# Altium schematic sheet UWB_Transceiver.SchDoc(U_UWB_Transceiver)
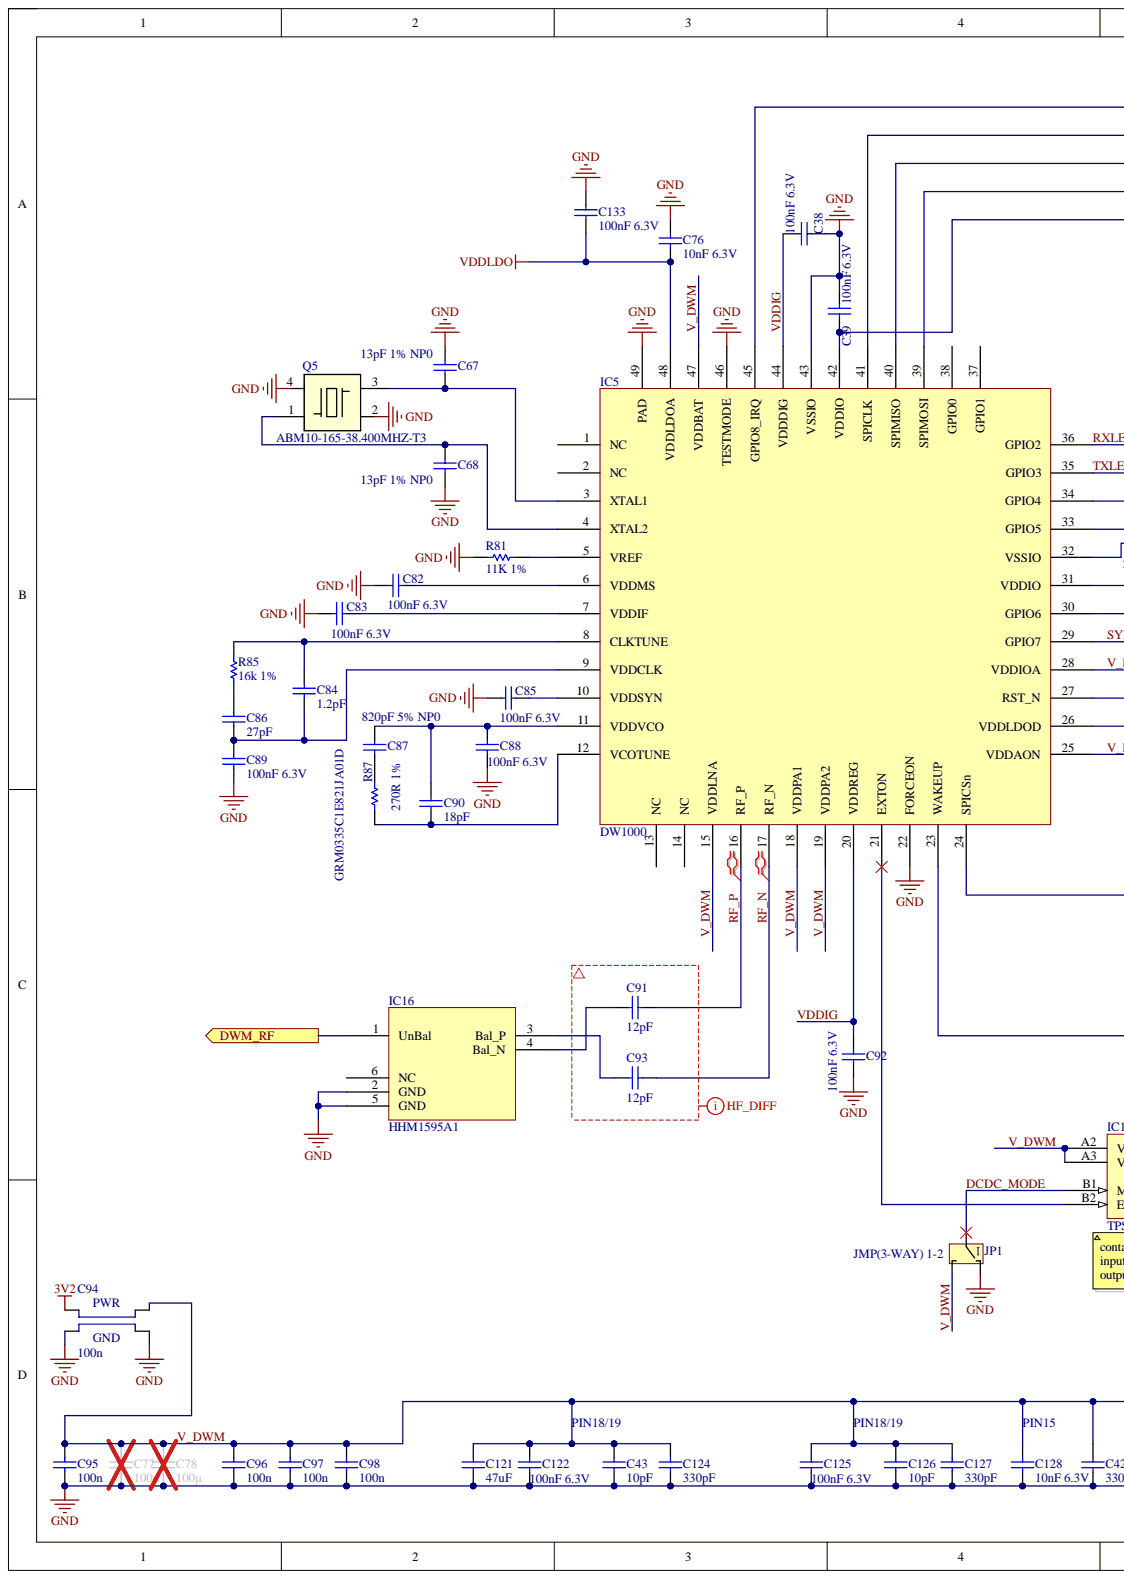
[diagram p0: UWB_Transceiver.SchDoc, left]
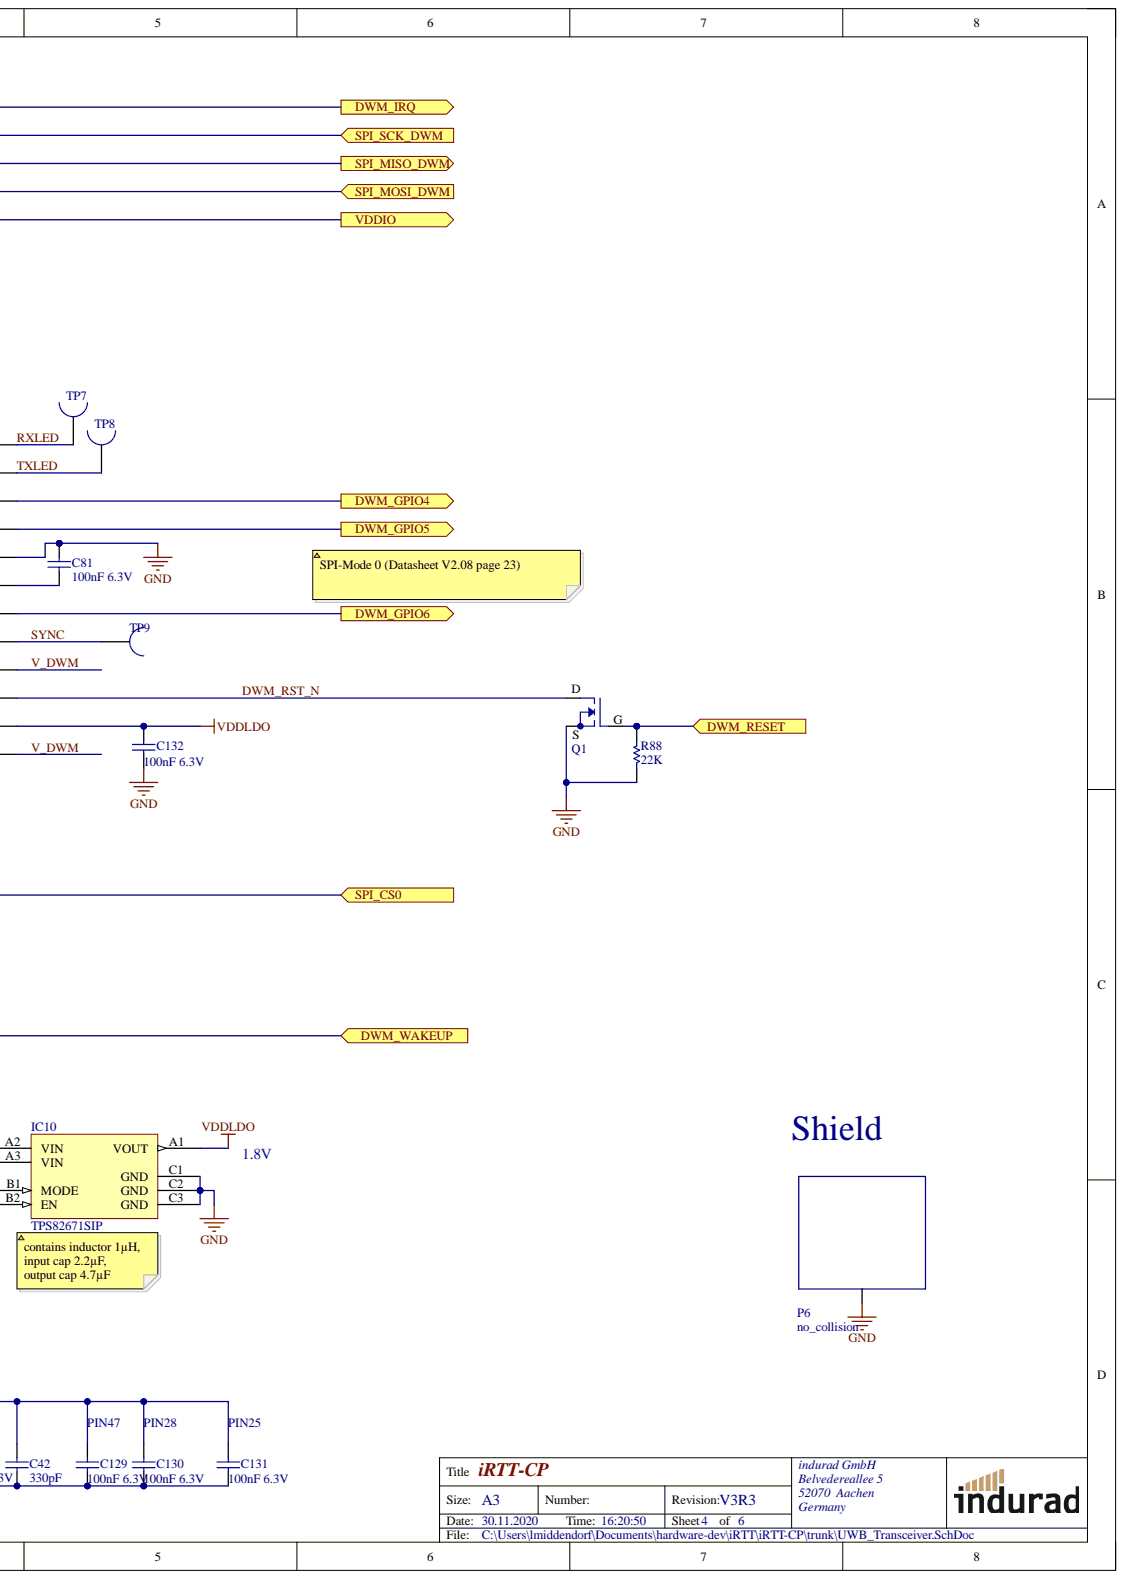
[diagram p1: UWB_Transceiver.SchDoc, right]

## Parts
C38  100nF 6.3V  Voltage - Rated: 6.3V  [0201_N_(0603)]
C39  100nF 6.3V  Voltage - Rated: 6.3V  [0201_N_(0603)]
C42  330pF  [0201_N_(0603)]
C43  10pF  [0201_N_(0603)]
C67  13pF 1% NP0  [0201_N_(0603)]
C68  13pF 1% NP0  [0201_N_(0603)]
C76  10nF 6.3V  Voltage - Rated: 6.3V  [0201_N_(0603)]
C77  100µ  [1206_N_(3216)]
C78  100µ  [1206_N_(3216)]
C81  100nF 6.3V  [0201_N_(0603)]
C82  100nF 6.3V  Voltage - Rated: 6.3V  [0201_N_(0603)]
C83  100nF 6.3V  Voltage - Rated: 6.3V  [0201_N_(0603)]
C84  1.2pF  [0201_N_(0603)]
C85  100nF 6.3V  [0201_N_(0603)]
C86  27pF  [0201_N_(0603)]
C87  GRM0335C1E821JA01D  value 820pF 5% NP0  [0201_N_(0603)]
C88  100nF 6.3V  [0201_N_(0603)]
C89  100nF 6.3V  [0201_N_(0603)]
C90  18pF  [0201_N_(0603)]
C91  12pF  [0201_N_(0603)]
C92  100nF 6.3V  Voltage - Rated: 6.3V  [0201_N_(0603)]
C93  12pF  [0201_N_(0603)]
C94  100n  [0603_N_(1608) - 4_POL]
C95  100n  [0402_N_(1005)]
C96  100n  [0402_N_(1005)]
C97  100n  [0402_N_(1005)]
C98  100n  [0402_N_(1005)]
C121  47uF  [0805_N_(2012)]
C122  100nF 6.3V  Voltage - Rated: 6.3V  [0201_N_(0603)]
C124  330pF  [0201_N_(0603)]
C125  100nF 6.3V  Voltage - Rated: 6.3V  [0201_N_(0603)]
C126  10pF  [0201_N_(0603)]
C127  330pF  [0201_N_(0603)]
C128  10nF 6.3V  [0201_N_(0603)]
C129  100nF 6.3V  Voltage - Rated: 6.3V  [0201_N_(0603)]
C130  100nF 6.3V  Voltage - Rated: 6.3V  [0201_N_(0603)]
C131  100nF 6.3V  Voltage - Rated: 6.3V  [0201_N_(0603)]
C132  100nF 6.3V  Voltage - Rated: 6.3V  [0201_N_(0603)]
C133  100nF 6.3V  [0201_N_(0603)]
IC5  DW1000  [QFN48 DW1000]
IC10  TPS82671SIP  [uSIP8]
IC16  HHM1595A1  [0805 6pol]
JP1  JMP(3-WAY) 1-2  [JM0402(SET_1_2)(3WAY)]
P6  ClassName: no_collision  [SMD Shielding Cabinet with clips 13.66 X 12.7mm_UWB]  (hidden comment: SMD Shield with clips 13,66x12,70x2,54x0,20)
Q1  N-MOSFET  [SOT883]  (value not drawn)
Q5  ABM10-165-38.400MHZ-T3  [Osz-2.5x2.0]
R81  11K 1%  [0201_N_(0603)]
R85  16k 1%  [0201_N_(0603)]
R87  270R 1%  [0201_N_(0603)]
R88  22K  [0201_N_(0603)]
TP7  TP  [TP0.6d0.3]  (no BOM)
TP8  TP  [TP0.6d0.3]  (no BOM)
TP9  TP  [TP0.6d0.3]  (no BOM)

## Ports
DWM_GPIO4, DWM_GPIO5, DWM_GPIO6, DWM_IRQ, DWM_RESET, DWM_RF, DWM_WAKEUP, SPI_CS0, SPI_MISO_DWM, SPI_MOSI_DWM, SPI_SCK_DWM, VDDIO

## Nets
3V2: C94.1
GND: C38.1 C39.2 C42.1 C43.1 C67.2 C68.1 C76.1 C77.1 C78.1 C81.1 C82.2 C83.2 C85.2 C88.2 C89.1 C92.1 C94.2 C94.4 C95.1 C96.1 C97.1 C98.1 C121.1 C122.2 C124.1 C125.2 C126.1 C127.1 C128.1 C129.2 C130.2 C131.2 C132.2 C133.2 IC5.22(FORCEON) IC5.32(VSSIO) IC5.43(VSSIO) IC5.46(TESTMODE) IC5.49(PAD) IC10.C1(GND) IC10.C2(GND) IC10.C3(GND) IC16.2(GND) IC16.5(GND) JP1.1 P6.1 Q1.2 Q5.2 Q5.4 R81.1 R88.2
VDDLDO: C76.2 C132.1 C133.1 IC5.26(VDDLDOD) IC5.48(VDDLDOA) IC10.A1(VOUT)
DCDC_MODE: IC10.B1(MODE) JP1.2
DWM_GPIO4: IC5.34(GPIO4) ; port DWM_GPIO4
DWM_GPIO5: IC5.33(GPIO5) ; port DWM_GPIO5
DWM_GPIO6: IC5.30(GPIO6) ; port DWM_GPIO6
DWM_IRQ: IC5.45(GPIO8_IRQ) ; port DWM_IRQ
DWM_RESET: Q1.1 R88.1 ; port DWM_RESET
DWM_RF: IC16.1(UnBal) ; port DWM_RF
DWM_RST_N: IC5.27(RST_N) Q1.3
DWM_WAKEUP: IC5.23(WAKEUP) ; port DWM_WAKEUP
RF_N: C93.2 IC5.17(RF_N)
RF_P: C91.2 IC5.16(RF_P)
RXLED: IC5.36(GPIO2) TP7.1
SPI_CS0: IC5.24(SPICSn) ; port SPI_CS0
SPI_MISO_DWM: IC5.40(SPIMISO) ; port SPI_MISO_DWM
SPI_MOSI_DWM: IC5.39(SPIMOSI) ; port SPI_MOSI_DWM
SPI_SCK_DWM: IC5.41(SPICLK) ; port SPI_SCK_DWM
SYNC: IC5.29(GPIO7) TP9.1
TXLED: IC5.35(GPIO3) TP8.1
V_DWM: C42.2 C43.2 C77.2 C78.2 C94.3 C95.2 C96.2 C97.2 C98.2 C121.2 C122.1 C124.2 C125.1 C126.2 C127.2 C128.2 C129.1 C130.1 C131.1 IC5.15(VDDLNA) IC5.18(VDDPA1) IC5.19(VDDPA2) IC5.25(VDDAON) IC5.28(VDDIOA) IC5.47(VDDBAT) IC10.A2(VIN) IC10.A3(VIN) JP1.3
VDDIG: C38.2 C92.2 IC5.20(VDDREG) IC5.44(VDDDIG)
VDDIO: C39.1 IC5.42(VDDIO) ; port VDDIO
NetC67_1: C67.1 IC5.3(XTAL1) Q5.3
NetC68_2: C68.2 IC5.4(XTAL2) Q5.1
NetC81_2: C81.2 IC5.31(VDDIO)
NetC82_1: C82.1 IC5.6(VDDMS)
NetC83_1: C83.1 IC5.7(VDDIF)
NetC84_1: C84.1 C86.1 C89.2 IC5.9(VDDCLK)
NetC84_2: C84.2 IC5.8(CLKTUNE) R85.2
NetC85_1: C85.1 IC5.10(VDDSYN)
NetC86_2: C86.2 R85.1
NetC87_1: C87.1 R87.2
NetC87_2: C87.2 C88.1 C90.2 IC5.11(VDDVCO)
NetC90_1: C90.1 IC5.12(VCOTUNE) R87.1
NetC91_1: C91.1 IC16.4(Bal_N)
NetC93_1: C93.1 IC16.3(Bal_P)
NetIC5_1: IC5.1(NC)
NetIC5_2: IC5.2(NC)
NetIC5_5: IC5.5(VREF) R81.2
NetIC5_13: IC5.13(NC)
NetIC5_14: IC5.14(NC)
NetIC5_21: IC5.21(EXTON) IC10.B2(EN)
NetIC5_37: IC5.37(GPIO1)
NetIC5_38: IC5.38(GPIO0)
NetIC16_6: IC16.6(NC)

## Library data of the parts
C38 C39 C81-C83 C85 C88 C89 C92 C122 C125 C129-C133 = Cap: Capacitor; Manufacturer: TDK Corporation; Manufacturer Part Number: C0603X5R0J104K030BC; Capacitance: 0.10µF; Temperature Coefficient: X5R; Tolerance: ±10%; Applications: General Purpose; Category: Capacitors; Component Kind: Standard; ComponentLink1Description: https://product.tdk.com/info/en/catalog/datasheets/mlcc_commercial_general_en.pdf; ComponentLink1URL: https://product.tdk.com/info/en/catalog/datasheets/mlcc_commercial_general_en.pdf; Features: -; Height - Seated (Max): -; Lead Spacing: -; Lead Style: -; Mounting Type: Surface Mount, MLCC; Operating Temperature: -55°C ~ 85°C; Package / Case: 0201 (0603 Metric); Packaging: Cut Tape (CT); Pricing 1: 1=0.09, 10=0.028, 100=0.0131, 250=0.01072, 500=0.00916, 1000=0.00713, 5000=0.00594 (EUR); Ratings: -; RoHS: RoHS Compliant; Signal Integrity: Cap; Simulation: CAP; Size / Dimension: 0.024" L x 0.012" W (0.60mm x 0.30mm); Stock 1: 1826831; Supplier 1: Digi-Key; Supplier Part Number 1: 445-1796-1-ND; Thickness (Max): 0.013" (0.33mm); Value: 100nF 6.3V; Library Name: Indurad.SchLib
  C81 C85 C88 C89 C133: Voltage - Rated: 6.3V
C42 C124 C127 = Cap: Capacitor; Manufacturer: Murata Electronics North America; Manufacturer Part Number: GRM033R71E331KA01D; Temperature Coefficient: X7R; Tolerance: ±10%; Voltage - Rated: 25V; Applications: General Purpose; Capacitance: 330pF; Category: Capacitors; Component Kind: Standard; ComponentLink1Description: http://www.murata.com/~/media/webrenewal/support/library/catalog/products/capacitor/mlcc/c02e.pdf; ComponentLink1URL: http://www.murata.com/~/media/webrenewal/support/library/catalog/products/capacitor/mlcc/c02e.pdf; Features: -; Height - Seated (Max): -; Lead Spacing: -; Lead Style: -; Mounting Type: Surface Mount, MLCC; Operating Temperature: -55°C ~ 125°C; Package / Case: 0201 (0603 Metric); Packaging: Cut Tape (CT); Pricing 1: 1=0.1, 10=0.013, 50=0.0076, 100=0.0064, 250=0.00524, 500=0.0045, 1000=0.0035, 5000=0.00292 (EUR); Ratings: -; RoHS: RoHS Compliant; Signal Integrity: Cap; Simulation: CAP; Size / Dimension: 0.024" L x 0.012" W (0.60mm x 0.30mm); Stock 1: 380472; Supplier 1: Digi-Key; Supplier Part Number 1: 490-3178-1-ND; Thickness (Max): 0.013" (0.33mm); Value: 330pF; Library Name: Indurad.SchLib
C43 C126 = Cap: Capacitor; Manufacturer: Samsung Electro-Mechanics America, Inc.; Manufacturer Part Number: CL03C100JA3GNNC; Temperature Coefficient: C0G, NP0; Tolerance: ±5%; Voltage - Rated: 25V; Applications: General Purpose; Capacitance: 10pF; Category: Capacitors; Component Kind: Standard; ComponentLink1Description: http://www.samsungsem.com/kr/support/product-search/mlcc/CL03C100JA3GNNC.jsp; ComponentLink1URL: http://www.samsungsem.com/kr/support/product-search/mlcc/CL03C100JA3GNNC.jsp; Features: -; Height - Seated (Max): -; Lead Spacing: -; Lead Style: -; Mounting Type: Surface Mount, MLCC; Operating Temperature: -55°C ~ 125°C; Package / Case: 0201 (0603 Metric); Packaging: Cut Tape (CT); Pricing 1: 1=0.09, 10=0.015, 25=0.0104, 50=0.008, 100=0.0068, 500=0.00476, 1000=0.0037, 5000=0.00308 (EUR); Ratings: -; RoHS: RoHS Compliant; Signal Integrity: Cap; Simulation: CAP; Size / Dimension: 0.024" L x 0.012" W (0.60mm x 0.30mm); Stock 1: 189069; Supplier 1: Digi-Key; Supplier Part Number 1: 1276-1384-1-ND; Thickness (Max): 0.013" (0.33mm); Value: 10pF; Library Name: Indurad.SchLib
C67 C68 = Cap: Capacitor; Manufacturer: KEMET; Manufacturer Part Number: CBR02C130F3GAC; Temperature Coefficient: C0G, NP0; Voltage - Rated: 25V; Applications: RF, Microwave, High Frequency; Capacitance: 13pF; Category: Capacitors; Component Kind: Standard; ComponentLink1Description: http://www.kemet.com/docfinder?Partnumber=CBR02C130F3GAC; ComponentLink1URL: http://www.kemet.com/docfinder?Partnumber=CBR02C130F3GAC; Failure Rate: -; Features: High Q, Low Loss; Height - Seated (Max): -; Lead Spacing: -; Lead Style: -; Mounting Type: Surface Mount, MLCC; Operating Temperature: -55°C ~ 125°C; Package / Case: 0201 (0603 Metric); Packaging: Cut Tape (CT); Part Status: Active; Pricing 1: 1=0.31, 10=0.217, 100=0.1304, 500=0.09776, 1000=0.08256, 2500=0.07821, 5000=0.07386 (EUR); Ratings: -; RoHS: RoHS Compliant; Signal Integrity: Cap; Simulation: CAP; Size / Dimension: 0.024" L x 0.012" W (0.60mm x 0.30mm); Stock 1: 9169; Supplier 1: Digi-Key; Supplier Part Number 1: 399-8570-1-ND; Thickness (Max): 0.013" (0.33mm); Tolerance: ±1%; Value: 13pF 1% NP0; Library Name: Indurad.SchLib
C76 C128 = Cap: Capacitor; Manufacturer: Murata Electronics North America; Manufacturer Part Number: GRM033R70J103KA01D; Capacitance: 10000pF; Temperature Coefficient: X7R; Tolerance: ±10%; Applications: General Purpose; Category: Capacitors; Component Kind: Standard; ComponentLink1Description: http://www.murata.com/~/media/webrenewal/support/library/catalog/products/capacitor/mlcc/c02e.pdf; ComponentLink1URL: http://www.murata.com/~/media/webrenewal/support/library/catalog/products/capacitor/mlcc/c02e.pdf; Features: -; Height - Seated (Max): -; Lead Spacing: -; Lead Style: -; Mounting Type: Surface Mount, MLCC; Operating Temperature: -55°C ~ 125°C; Package / Case: 0201 (0603 Metric); Packaging: Cut Tape (CT); Pricing 1: 1=0.09, 10=0.021, 50=0.0112, 100=0.0095, 250=0.00772, 500=0.0066, 1000=0.00514, 5000=0.00428 (EUR); Ratings: -; RoHS: RoHS Compliant; Signal Integrity: Cap; Simulation: CAP; Size / Dimension: 0.024" L x 0.012" W (0.60mm x 0.30mm); Stock 1: 506964; Supplier 1: Digi-Key; Supplier Part Number 1: 490-1262-1-ND; Thickness (Max): 0.013" (0.33mm); Value: 10nF 6.3V; Library Name: Indurad.SchLib
  C128: Voltage - Rated: 6.3V
C77 C78 = Cap: Capacitor; Manufacturer: Murata Electronics North America; Manufacturer Part Number: GRM31CR60J107ME39L; Capacitance: 100µF; Temperature Coefficient: X5R; Tolerance: ±20%; Voltage - Rated: 6.3V; Applications: General Purpose; Category: Capacitors; Component Kind: Standard; ComponentLink1Description: http://www.murata.com/~/media/webrenewal/support/library/catalog/products/capacitor/mlcc/c02e.ashx; ComponentLink1URL: http://www.murata.com/~/media/webrenewal/support/library/catalog/products/capacitor/mlcc/c02e.ashx; Features: -; Height - Seated (Max): -; Lead Spacing: -; Lead Style: -; Mounting Type: Surface Mount, MLCC; Operating Temperature: -55°C ~ 85°C; Package / Case: 1206 (3216 Metric); Packaging: Tape & Reel (TR); Ratings: -; RoHS: RoHS Compliant; Signal Integrity: Cap; Simulation: CAP; Size / Dimension: 0.126" L x 0.063" W (3.20mm x 1.60mm); Supplier 1: Digi-Key; Supplier Part Number 1: 490-4539-2-ND; Thickness (Max): 0.071" (1.80mm); Value: 100µ; Library Name: Indurad.SchLib
C84 = Cap: Capacitor; Manufacturer: Murata Electronics North America; Manufacturer Part Number: GRM0335C1H1R2CA01D; Temperature Coefficient: C0G, NP0; Tolerance: ±0.25pF; Voltage - Rated: 50V; Applications: General Purpose; Capacitance: 1.2pF; Category: Capacitors; Component Kind: Standard; ComponentLink1Description: http://www.murata.com/~/media/webrenewal/support/library/catalog/products/capacitor/mlcc/c02e.pdf; ComponentLink1URL: http://www.murata.com/~/media/webrenewal/support/library/catalog/products/capacitor/mlcc/c02e.pdf; Features: -; Height - Seated (Max): -; Lead Spacing: -; Lead Style: -; Mounting Type: Surface Mount, MLCC; Operating Temperature: -55°C ~ 125°C; Package / Case: 0201 (0603 Metric); Packaging: Cut Tape (CT); Pricing 1: 1=0.09, 10=0.02, 25=0.014, 50=0.011, 100=0.0092, 250=0.00752, 500=0.00644, 1000=0.00502, 5000=0.00418 (EUR); Ratings: -; RoHS: RoHS Compliant; Signal Integrity: Cap; Simulation: CAP; Size / Dimension: 0.024" L x 0.012" W (0.60mm x 0.30mm); Stock 1: 179592; Supplier 1: Digi-Key; Supplier Part Number 1: 490-6119-1-ND; Value: 1.2pF; Library Name: Indurad.SchLib
C86 = Cap: Capacitor; Manufacturer: Murata Electronics North America; Manufacturer Part Number: GRM0335C1E270JA01D; Temperature Coefficient: C0G, NP0; Tolerance: ±5%; Voltage - Rated: 25V; Applications: General Purpose; Capacitance: 27pF; Category: Capacitors; Component Kind: Standard; ComponentLink1Description: http://www.murata.com/~/media/webrenewal/support/library/catalog/products/capacitor/mlcc/c02e.pdf; ComponentLink1URL: http://www.murata.com/~/media/webrenewal/support/library/catalog/products/capacitor/mlcc/c02e.pdf; Features: -; Height - Seated (Max): -; Lead Spacing: -; Lead Style: -; Mounting Type: Surface Mount, MLCC; Operating Temperature: -55°C ~ 125°C; Package / Case: 0201 (0603 Metric); Packaging: Cut Tape (CT); Pricing 1: 1=0.09, 50=0.0036, 100=0.0029, 250=0.0024, 500=0.00204, 1000=0.00158, 5000=0.00132 (EUR); Ratings: -; RoHS: RoHS Compliant; Signal Integrity: Cap; Simulation: CAP; Size / Dimension: 0.024" L x 0.012" W (0.60mm x 0.30mm); Stock 1: 408508; Supplier 1: Digi-Key; Supplier Part Number 1: 490-3150-1-ND; Thickness (Max): 0.013" (0.33mm); Value: 27pF; Library Name: Indurad.SchLib
C87 = Cap: Capacitor; Manufacturer: Murata; Component Kind: Standard; Manufacturer Part Number: GRM0335C1E821JA01D; Signal Integrity: Cap; Simulation: CAP; Library Name: Indurad.SchLib
C90 = Cap: Capacitor; Manufacturer: Murata Electronics North America; Manufacturer Part Number: GRM0335C1H180JA01D; Temperature Coefficient: C0G, NP0; Tolerance: ±5%; Voltage - Rated: 50V; Applications: General Purpose; Capacitance: 18pF; Category: Capacitors; Component Kind: Standard; ComponentLink1Description: http://www.murata.com/~/media/webrenewal/support/library/catalog/products/capacitor/mlcc/c02e.pdf; ComponentLink1URL: http://www.murata.com/~/media/webrenewal/support/library/catalog/products/capacitor/mlcc/c02e.pdf; Features: -; Height - Seated (Max): -; Lead Spacing: -; Lead Style: -; Mounting Type: Surface Mount, MLCC; Operating Temperature: -55°C ~ 125°C; Package / Case: 0201 (0603 Metric); Packaging: Cut Tape (CT); Pricing 1: 1=0.09, 10=0.02, 50=0.011, 100=0.0092, 250=0.00752, 500=0.00644, 1000=0.00502, 5000=0.00418 (EUR); Ratings: -; RoHS: RoHS Compliant; Signal Integrity: Cap; Simulation: CAP; Size / Dimension: 0.024" L x 0.012" W (0.60mm x 0.30mm); Stock 1: 153894; Supplier 1: Digi-Key; Supplier Part Number 1: 490-6117-1-ND; Value: 18pF; Library Name: Indurad.SchLib
C91 C93 = Cap: Capacitor; Manufacturer: Murata Electronics North America; Manufacturer Part Number: GRM0335C1E120JA01D; Temperature Coefficient: C0G, NP0; Tolerance: ±5%; Voltage - Rated: 25V; Applications: General Purpose; Capacitance: 12pF; Category: Capacitors; Component Kind: Standard; ComponentLink1Description: http://www.murata.com/~/media/webrenewal/support/library/catalog/products/capacitor/mlcc/c02e.pdf; ComponentLink1URL: http://www.murata.com/~/media/webrenewal/support/library/catalog/products/capacitor/mlcc/c02e.pdf; Features: -; Height - Seated (Max): -; Lead Spacing: -; Lead Style: -; Mounting Type: Surface Mount, MLCC; Operating Temperature: -55°C ~ 125°C; Package / Case: 0201 (0603 Metric); Packaging: Cut Tape (CT); Pricing 1: 1=0.09, 50=0.0036, 100=0.0029, 250=0.0024, 500=0.00204, 1000=0.00158, 5000=0.00132 (EUR); Ratings: -; RoHS: RoHS Compliant; Signal Integrity: Cap; Simulation: CAP; Size / Dimension: 0.024" L x 0.012" W (0.60mm x 0.30mm); Stock 1: 273257; Supplier 1: Digi-Key; Supplier Part Number 1: 490-3144-1-ND; Thickness (Max): 0.013" (0.33mm); Value: 12pF; Library Name: Indurad.SchLib
C94 = Cap 4 Pol 16V: Capacitor 4 pol 16V; Manufacturer: TDK Corporation; Manufacturer Part Number: YFF18PC1C104M; Capacitance: 0.1µF; Current: 4A; Category: Filters; Component Kind: Standard; ComponentLink1Description: https://product.tdk.com/info/en/catalog/datasheets/emc_esr_yff-p_en.pdf; ComponentLink1URL: https://product.tdk.com/info/en/catalog/datasheets/emc_esr_yff-p_en.pdf; DC Resistance (DCR): -; Height (Max): 0.035" (0.90mm); Operating Temperature: -55°C ~ 125°C; Package / Case: 0603 (1608 Metric), 4 PC Pad; Packaging: Cut Tape (CT); Pricing 1: 1=0.48, 10=0.46, 100=0.223, 250=0.18524, 500=0.16806, 1000=0.15434 (EUR); RoHS: RoHS Compliant; Size / Dimension: 0.063" L x 0.031" W (1.60mm x 0.80mm); Stock 1: 4170; Supplier 1: Digi-Key; Supplier Part Number 1: 445-16133-1-ND; Value: 100n; Voltage: 16V; Library Name: Indurad.SchLib
C95-C98 = Cap: Capacitor; Manufacturer: Murata Electronics North America; Manufacturer Part Number: GRM155R70J104KA01D; Capacitance: 0.1µF; Temperature Coefficient: X7R; Tolerance: ±10%; Voltage - Rated: 6.3V; Applications: General Purpose; Category: Capacitors; Component Kind: Standard; ComponentLink1Description: http://www.murata.com/~/media/webrenewal/support/library/catalog/products/capacitor/mlcc/c02e.ashx; ComponentLink1URL: http://www.murata.com/~/media/webrenewal/support/library/catalog/products/capacitor/mlcc/c02e.ashx; Features: -; Height - Seated (Max): -; Lead Spacing: -; Mounting Type: Surface Mount, MLCC; Operating Temperature: -55°C ~ 125°C; Package / Case: 0402 (1005 Metric); Packaging: Cut Tape (CT); Pricing 1: 1=0.1, 10=0.025, 50=0.0136, 100=0.0114, 250=0.00936, 500=0.008, 1000=0.00622, 5000=0.00519 (EUR); Ratings: -; RoHS: RoHS Compliant; Signal Integrity: Cap; Simulation: CAP; Size / Dimension: 0.039" L x 0.020" W (1.00mm x 0.50mm); Stock 1: 430852; Supplier 1: Digi-Key; Supplier Part Number 1: 490-6319-1-ND; Thickness (Max): 0.022" (0.55mm); Value: 100n; Library Name: Indurad.SchLib
C121 = Cap: Capacitor; Manufacturer: Kemet; Manufacturer Part Number: C0805C476M9PACTU; Capacitance: 47µF; Temperature Coefficient: X5R; Tolerance: ±20%; Voltage - Rated: 6.3V; Applications: General Purpose; Category: Capacitors; Component Kind: Standard; ComponentLink1Description: http://www.kemet.com/docfinder?Partnumber=C0805C476M9PACTU; ComponentLink1URL: http://www.kemet.com/docfinder?Partnumber=C0805C476M9PACTU; Features: -; Height - Seated (Max): -; Lead Spacing: -; Lead Style: -; Mounting Type: Surface Mount, MLCC; Operating Temperature: -55°C ~ 85°C; Package / Case: 0805 (2012 Metric); Packaging: Cut Tape (CT); Pricing 1: 1=0.77, 10=0.649, 50=0.4774, 100=0.3794, 250=0.31816, 500=0.2876, 1000=0.26311 (EUR); Ratings: -; RoHS: RoHS Compliant; Signal Integrity: Cap; Simulation: CAP; Size / Dimension: 0.079" L x 0.049" W (2.00mm x 1.25mm); Stock 1: 384328; Supplier 1: Digi-Key; Supplier Part Number 1: 399-5506-1-ND; Thickness (Max): 0.055" (1.40mm); Value: 47uF; Library Name: Indurad.SchLib
IC5 = DW1000: UWB Decawave; Manufacturer: Decawave Limited; Manufacturer Part Number: DW1000-I-TR13; Current - Receiving: 64mA; Current - Transmitting: 31mA; Data Rate (Max): 6.8Mbps; Frequency: 3.5GHz ~ 6.5GHz; Modulation: BPM, BPSK; Power - Output: -10dBm; Protocol: IR-UWB; Serial Interfaces: SPI; Voltage - Supply: 2.8 V ~ 3.6 V; Category: RF/IF and RFID; Component Kind: Standard; ComponentLink2Description: Data Sheet; ComponentLink2URL: https://www.decawave.com/sites/default/files/dw1000-datasheet-v2.15.pdf; ComponentLink3Description: Product Page; ComponentLink3URL: http://www.decawave.com/products/dw1000; GPIO: -; Memory Size: -; Operating Temperature: -40°C ~ 85°C; Package / Case: 48-QFN Exposed Pad; Packaging: Cut Tape (CT); Pricing 1: 1=13.37, 10=12.102, 25=11.1464, 100=10.1908, 250=9.55388, 500=8.91696, 1000=8.47111 (EUR); RF Family/Standard: 802.15.4; RoHS: RoHS Compliant; Sensitivity: -; Stock 1: 11890; Supplier 1: Digi-Key; Supplier Part Number 1: 1479-1001-1-ND; Type: TxRx Only; Library Name: Indurad.SchLib
IC10 = TPS82671SIP: 1.8V 600-mA, High-Efficiency MicroSiP Step-Down Converter; Manufacturer: Texas Instruments; Manufacturer Part Number: TPS82671SIPT; Efficiency: 90%; Max Input Voltage: 4.8V; Max Output Current: 600mA; Min Input Voltage: 2.3V; Number of Outputs: 1; Quiescent Current: 17uA; Switching Frequency: 6MHz; Synchronous Rectifier: Yes; Case/Package: SIP; Component Kind: Standard; ComponentLink1Description: Product page; ComponentLink1URL: http://www.ti.com/product/TPS82671; ComponentLink2Description: Datasheet; ComponentLink2URL: http://www.ti.com/lit/ds/symlink/tps82671.pdf; Height: 0.9mm; Lead Free: Lead Free; Length: 2.95mm; Max Operating Temperature: 85°C; Max Output Voltage: 1.8V; Min Operating Temperature: -40°C; Mount: Surface Mount; Nominal Output Voltage: 1.8V; Number of Pins: 8; Output Current: 600mA; Output Voltage: 1.8V; Package Quantity: 1; Packaging: Tape and Reel; Radiation Hardening: No; REACH SVHC: No SVHC; Supplier 1: Digi-Key; Supplier Part Number 1: 296-27939-1-ND; Width: 2.35mm; Library Name: Indurad.SchLib
IC16 = HHM1595A1: XFMR BALUN RF 3.0-8.0GHZ UWB SMD; Manufacturer: TDK Corporation; Frequency Range: 3GHz ~ 8GHz; Impedance - Unbalanced/Balanced: 50 / 100 Ohm; Insertion Loss (Max): 1.5dB; Phase Difference: 180° ±20; Return Loss (Min): 8dB; Category: RF/IF and RFID; Component Kind: Standard; ComponentLink1Description: https://product.tdk.com/info/en/documents/data_sheet/rf_balun_hhm1595a1_en.pdf; ComponentLink1URL: https://product.tdk.com/info/en/documents/data_sheet/rf_balun_hhm1595a1_en.pdf; Manufacturer Part Number: HHM1595A1; Mounting Type: Surface Mount; Package / Case: 0805 (2012 Metric); Packaging: Cut Tape (CT); Pricing 1: 1=1.21, 10=1.187, 100=0.6476, 250=0.58108, 500=0.56896, 1000=0.41159 (EUR); RoHS: RoHS Compliant; Stock 1: 4778; Supplier 1: Digi-Key; Supplier Part Number 1: 445-3387-1-ND; Library Name: Indurad.SchLib
JP1 = JMP(3-WAY) 1-2: 0R 0402; Value: 0; Component Kind: Standard; Library Name: JP_Jumper.SchLib
P6 = SMD Shield with clips 13,66x12,70x2,54x0,20: SMD Shielding Cabinet 13,66x12,70x2,54x0,20; Manufacturer: MTC; Manufacturer Part Number: SGH-13,66x12,70x2,54x0,20-FS-SC / 3X SC-6,50x0,80x1,27-TC-0,15; EMS Note: multiple parts per BOM item; Component Kind: Standard; Supplier 1: MTC; Supplier Part Number 1: SGH-13,66x12,70x2,54x0,20-FS-SC / 3X SC-6,50x0,80x1,27-TC-0,15; Library Name: Indurad.SchLib
Q1 = MOSFET-N SSM3K15ACT: N-Channel MOSFET SSM3K15ACT; Manufacturer: Toshiba Semiconductor and Storage; Manufacturer Part Number: SSM3K15ACT,L3F; Current - Continuous Drain (Id) @ 25°C: 100mA (Ta); Drain to Source Voltage (Vdss): 30V; FET Feature: Logic Level Gate, 2.5V Drive; FET Type: MOSFET N-Channel, Metal Oxide; Input Capacitance (Ciss) @ Vds: 13.5pF @ 3V; Power - Max: 100mW; Rds On (Max) @ Id, Vgs: 3.6 Ohm @ 10mA, 4V; Vgs(th) (Max) @ Id: 1.5V @ 100µA; Category: Discrete Semiconductor Products; Component Kind: Standard; ComponentLink1Description: https://toshiba.semicon-storage.com/info/docget.jsp?did=5867&prodName=SSM3K15ACT; ComponentLink1URL: https://toshiba.semicon-storage.com/info/docget.jsp?did=5867&prodName=SSM3K15ACT; Gate Charge (Qg) @ Vgs: -; Library Name: Q_Transistor.SchLib; Mounting Type: Surface Mount; Operating Temperature: 150°C (TJ); Package / Case: SC-101, SOT-883; Packaging: Cut Tape (CT); Part Status: Active; Pricing 1: 1=0.31, 10=0.289, 25=0.208, 100=0.1621, 250=0.10188, 500=0.0868, 1000=0.05902, 2500=0.05324, 5000=0.04629 (EUR); RoHS: RoHS Compliant; Stock 1: 2366; Supplier 1: Digi-Key; Supplier 2: Mouser; Supplier Device Package: CST3; Supplier Part Number 1: SSM3K15ACTL3FCT-ND; Supplier Part Number 2: 757-SSM3K15ACTL3F
Q5 = ABM10-165-38.400MHZ-T3: 38,4MHz, Kristall, 10pF, 40 Ohm, -30°C bis 85°C; Manufacturer: Abracon LLC; ESR (Equivalent Series Resistance): 40 Ohm; Frequency: 38.4MHz; Frequency Stability: -15ppm, +20ppm; Operating Mode: Fundamental; Type: MHz Crystal; Category: Crystals and Oscillators; Component Kind: Standard; ComponentLink1Description: http://www.abracon.com/Resonators/ABM10-165.pdf; ComponentLink1URL: http://www.abracon.com/Resonators/ABM10-165.pdf; Frequency Tolerance: -; Height: 0.020" (0.50mm); Load Capacitance: 10pF; Manufacturer Part Number: ABM10-165-38.400MHZ-T3; Mounting Type: Surface Mount; Operating Temperature: -30°C ~ 85°C; Package / Case: 4-SMD, No Lead (DFN, LCC); Packaging: Cut Tape (CT); Pricing 1: 1=1.11, 10=0.98, 50=0.9152, 100=0.81, 500=0.76542, 1000=0.63987 (EUR); Ratings: -; RoHS: RoHS Compliant; Size / Dimension: 0.098" L x 0.079" W (2.50mm x 2.00mm); Stock 1: 2557; Supplier 1: Digi-Key; Supplier Part Number 1: 535-13191-1-ND; Library Name: Indurad.SchLib
R81 R85 R87 R88 = Res: Resistor; Component Kind: Standard; Signal Integrity: Res; Simulation: RESISTOR; Library Name: Indurad.SchLib
  R81: Value: 11K 1%
  R85: Value: 16k 1%
  R87: Value: 270R 1%
  R88: Value: 22K
TP7-TP9 = TP: Generic test point; Library Name: InduradCommon.SchLib
